# Altium schematic sheet 2001-SCM-0001-06.02 TITLE.SchDoc("2001-SCM-0001-06.02 TITLE")
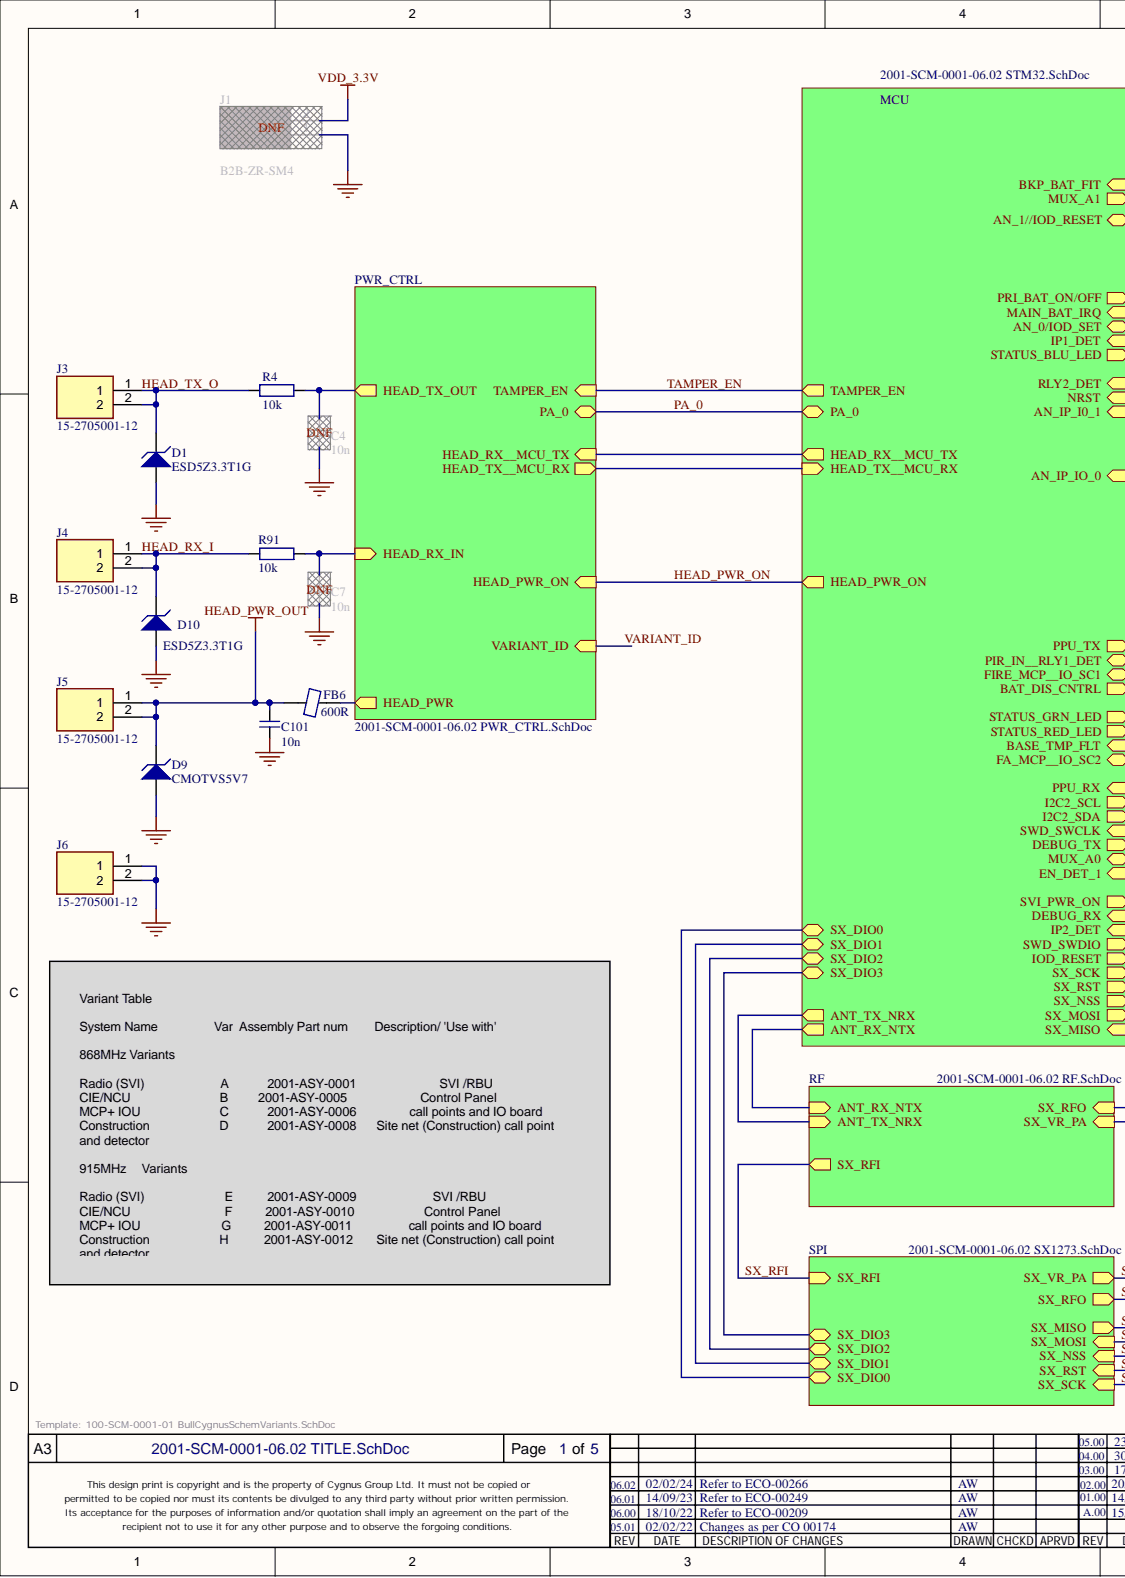
[diagram p0: 2001-SCM-0001-06.02 TITLE.SchDoc, left]
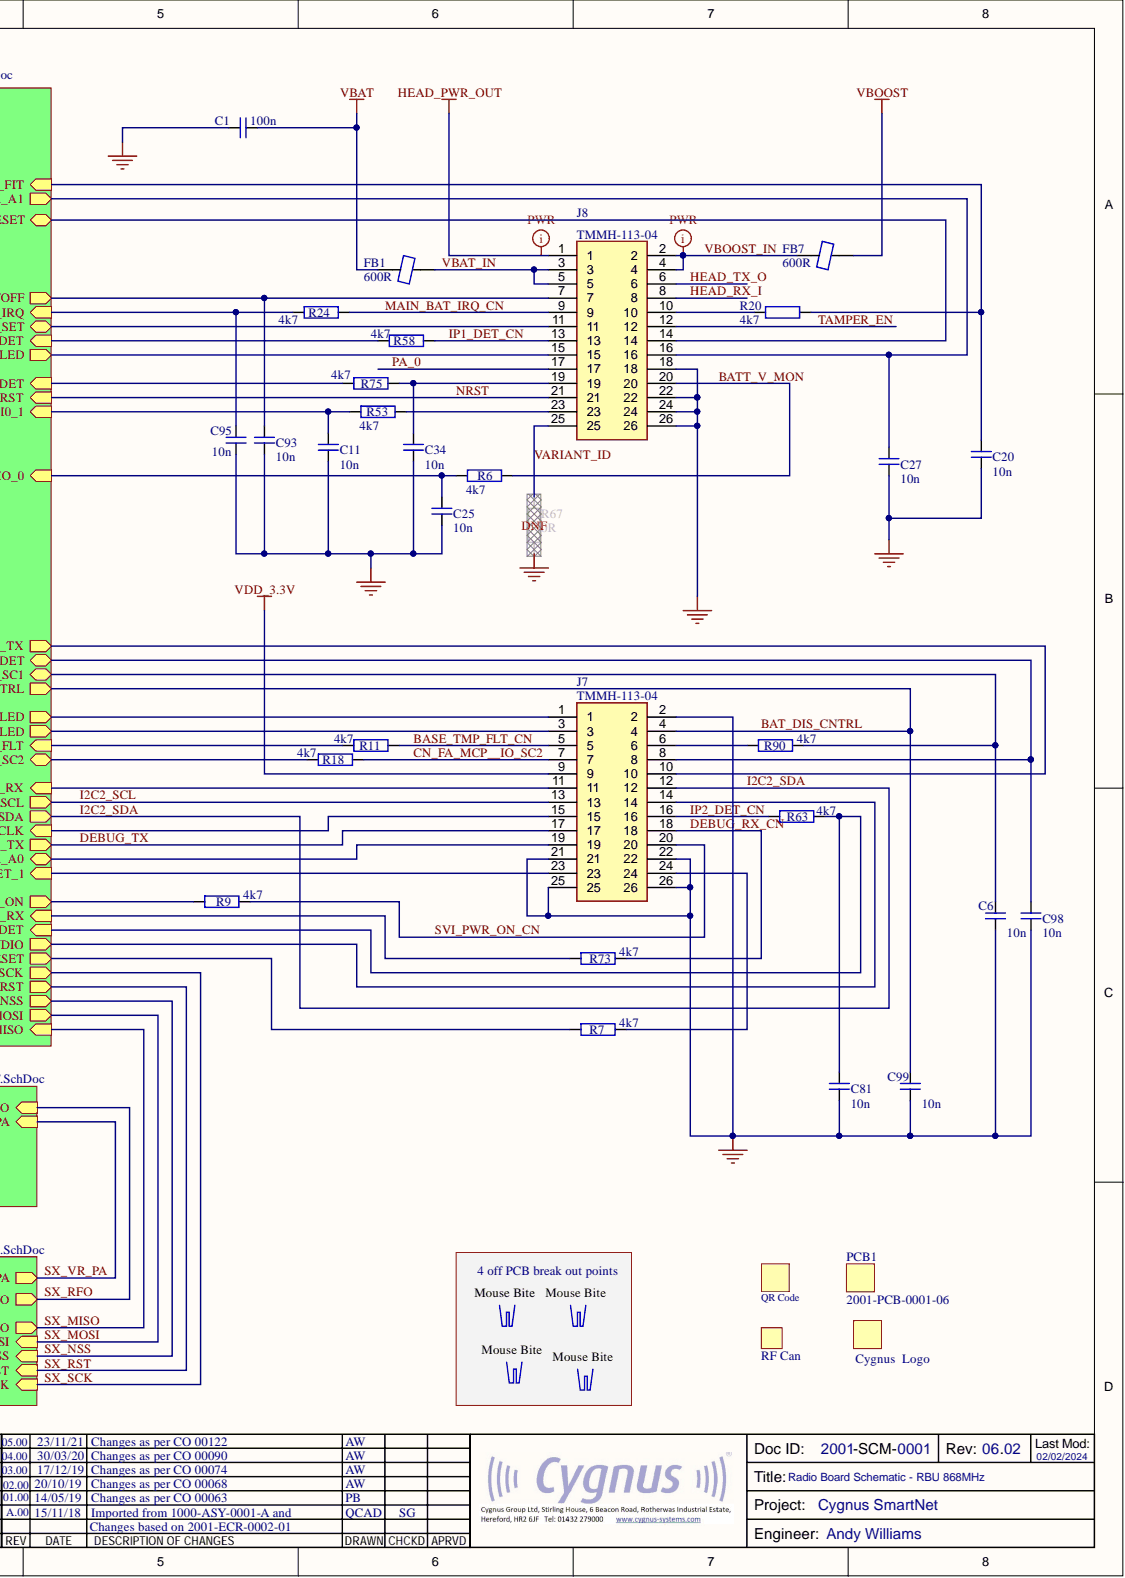
[diagram p1: 2001-SCM-0001-06.02 TITLE.SchDoc, right]

## Parts
C1  value 100n  [CAPC1608X95L]  (hidden comment: 100n 0603 16V X7R 10%)
C4  value 10n  [CAPC1608X95L]  (hidden comment: 10n 0603 16V X7R 10%)
C6  value 10n  [CAPC1608X95L]  (hidden comment: 10n 0603 16V X7R 10%)
C7  value 10n  [CAPC1608X95L]  (hidden comment: 10n 0603 16V X7R 10%)
C11  value 10n  [CAPC1608X95N]  (hidden comment: 10n 0603 16V X7R 10%)
C20  value 10n  [CAPC1608X95L]  (hidden comment: 10n 0603 16V X7R 10%)
C25  value 10n  [CAPC1608X95N]  (hidden comment: 10n 0603 16V X7R 10%)
C27  value 10n  [CAPC1608X95L]  (hidden comment: 10n 0603 16V X7R 10%)
C34  value 10n  [CAPC1608X95L]  (hidden comment: 10n 0603 16V X7R 10%)
C81  value 10n  [CAPC1608X95L]  (hidden comment: 10n 0603 16V X7R 10%)
C93  value 10n  [CAPC1608X95L]  (hidden comment: 10n 0603 16V X7R 10%)
C95  value 10n  [CAPC1608X95L]  (hidden comment: 10n 0603 16V X7R 10%)
C98  value 10n  [CAPC1608X95L]  (hidden comment: 10n 0603 16V X7R 10%)
C99  value 10n  [CAPC1608X95L]  (hidden comment: 10n 0603 16V X7R 10%)
C101  value 10n  [CAPC1608X95L]  (hidden comment: 10n 0603 16V X7R 10%)
D1  value ESD5Z3.3T1G  [SOD523_L]  (hidden comment: ESD5Z3.3T1G TVS Unidirectional SOD523 3V3 40A)
D9  value CMOTVS5V7  [SOD523_L]  (hidden comment: CMOTVS5V7 TVS Unidirectional SOD523 4.0V 40A)
D10  value ESD5Z3.3T1G  [SOD523_L]  (hidden comment: ESD5Z3.3T1G TVS Unidirectional SOD523 3V3 40A)
FB1  value 600R  [FBC1608X095L]  (hidden comment: 600R 0603 500mA 25%)
FB6  value 600R  [FBC1608X095L]  (hidden comment: 600R 0603 500mA 25%)
FB7  value 600R  [FBC1608X095L]  (hidden comment: 600R 0603 500mA 25%)
J1  value B2B-ZR-SM4  [CONN-JST-B2B-ZR-SM4-TF]  (hidden comment: JST B2B ZR 2Way SMD V 1P5)
J3  value 15-2705001-12  [CONN-NAE-15-0003]  (hidden comment: PCB Pin 15-2705001-12)
J4  value 15-2705001-12  [CONN-NAE-15-0003]  (hidden comment: PCB Pin 15-2705001-12)
J5  value 15-2705001-12  [CONN-NAE-15-0003]  (hidden comment: PCB Pin 15-2705001-12)
J6  value 15-2705001-12  [CONN-NAE-15-0003]  (hidden comment: PCB Pin 15-2705001-12)
J7  value TMMH-113-04  [CON_SAMTEC_TMMH-113-04-DV-ES-A-M-TR]  (hidden comment: Samtec TMMH 26Way SMD V 2P)
J8  value TMMH-113-04  [CON_SAMTEC_TMMH-113-04-DV-ES-A-M-TR]  (hidden comment: Samtec TMMH 26Way SMD V 2P)
Logo  Cygnus  Logo  [Cygnus Logo]  (no BOM)
MB1  MouseBite  [MouseBIte]  (no BOM)
MB2  MouseBite  [MouseBIte]  (no BOM)
MB3  MouseBite  [MouseBIte]  (no BOM)
MB4  MouseBite  [MouseBIte]  (no BOM)
Mech1  RF Can  (mechanical)
PCB1  2001-PCB-0001-06  (mechanical)
QR_Code  PCB Laser Etched QR Code  [PCB QR+HR CODE]  (value not drawn)  (no BOM)
R4  value 10k  [RESC1608L]  (hidden comment: 10K 0603 100mW 1%)
R6  value 4k7  [RESC1608L]  (hidden comment: 4K7 0603 100mW 1%)
R7  value 4k7  [RESC1608L]  (hidden comment: 4K7 0603 100mW 1%)
R9  value 4k7  [RESC1608L]  (hidden comment: 4K7 0603 100mW 1%)
R11  value 4k7  [RESC1608L]  (hidden comment: 4K7 0603 100mW 1%)
R18  value 4k7  [RESC1608L]  (hidden comment: 4K7 0603 100mW 1%)
R20  value 4k7  [RESC1608L]  (hidden comment: 4K7 0603 100mW 1%)
R24  value 4k7  [RESC1608L]  (hidden comment: 4K7 0603 100mW 1%)
R53  value 4k7  [RESC1608L]  (hidden comment: 4K7 0603 100mW 1%)
R58  value 4k7  [RESC1608L]  (hidden comment: 4K7 0603 100mW 1%)
R63  value 4k7  [RESC1608L]  (hidden comment: 4K7 0603 100mW 1%)
R67  value 0R  [RESC1608L]  (hidden comment: 0R 0603)
R73  value 4k7  [RESC1608L]  (hidden comment: 4K7 0603 100mW 1%)
R75  value 4k7  [RESC1608L]  (hidden comment: 4K7 0603 100mW 1%)
R90  value 4k7  [RESC1608L]  (hidden comment: 4K7 0603 100mW 1%)
R91  value 10k  [RESC1608L]  (hidden comment: 10K 0603 100mW 1%)

## Nets
GND: C1.2 C4.1 C6.2 C7.1 C11.1 C20.1 C25.1 C27.1 C34.1 C81.1 C93.1 C95.1 C98.2 C99.1 C101.1 D1.2 D9.2 D10.2 J1.1 J6.1 J6.2 J7.2 J7.21 J7.22 J7.25 J7.26 J8.18 J8.22 J8.24 J8.26 R67.2
HEAD_PWR_OUT: C101.2 D9.1 FB6.1 J5.1 J5.2 J8.1
VBAT: C1.1 FB1.1
VBOOST: FB7.2
VDD_3.3V: J1.2 J7.9
BASE_TMP_FLT_CN: J7.5 R11.1
BAT_DIS_CNTRL: C99.2 J7.4
BATT_V_MON: J8.20 R6.2
CN_FA_MCP__IO_SC2: J7.7 R18.1
DEBUG_RX_CN: J7.18 R73.1
DEBUG_TX: J7.17
HEAD_RX_I: D10.1 J4.1 J4.2 J8.8 R91.1
HEAD_TX_O: D1.1 J3.1 J3.2 J8.6 R4.1
I2C2_SCL: J7.13
I2C2_SDA: J7.12
IP1_DET_CN: J8.13 R58.2
IP2_DET_CN: J7.16 R63.2
MAIN_BAT_IRQ_CN: J8.9 R24.1
NRST: J8.21
PA_0: J8.17
SVI_PWR_ON_CN: J7.20 R9.1
TAMPER_EN: J8.12
VARIANT_ID: J8.25 R67.1
VBAT_IN: FB1.2 J8.3 J8.5
VBOOST_IN: FB7.1 J8.2 J8.4
NetC4_2: C4.2 R4.2
NetC6_1: C6.1 R90.1
NetC7_2: C7.2 R91.2
NetC11_2: C11.2 R53.2
NetC20_2: C20.2 R20.1
NetC25_2: C25.2 R6.1
NetC27_2: C27.2 J8.16
NetC34_2: C34.2 J8.19 R75.1
NetC81_2: C81.2 R63.1
NetC93_2: C93.2 J8.7
NetC95_2: C95.2 R24.2
NetC98_1: C98.1 J7.8
NetFB6_2: FB6.2
NetJ7_1: J7.1
NetJ7_3: J7.3
NetJ7_6: J7.6 R90.2
NetJ7_10: J7.10
NetJ7_11: J7.11
NetJ7_14: J7.14
NetJ7_15: J7.15
NetJ7_19: J7.19
NetJ7_23: J7.23
NetJ7_24: J7.24 R7.1
NetJ8_10: J8.10 R20.2
NetJ8_11: J8.11
NetJ8_14: J8.14
NetJ8_15: J8.15
NetJ8_23: J8.23 R53.1
NetR7_2: R7.2
NetR9_2: R9.2
NetR11_2: R11.2
NetR18_2: R18.2
NetR58_1: R58.1
NetR73_2: R73.2
NetR75_2: R75.2
HEAD_PWR_ON:
N00167:
N00168:
N00169:
N00170:
N00171:
N00172:
N00173:
N00174:
SX_MISO:
SX_MOSI:
SX_NSS:
SX_RFI:
SX_RFO:
SX_RST:
SX_SCK:
SX_VR_PA:

## Library data of the parts
C1 = 100n-0603-16V-X7R-10%: Voltage: 16V; Tolerance: 10%; Package: 0603; Manufacturer Part Number 1: 100n-0603-16V-X7R-10%; Library Name: Capacitors.IntLib; Dielectric: X7R; Description: 100n 0603 16V X7R 10%; Component Kind: Standard; Category: Ceramic
C4 C6 C7 C11 C20 C25 C27 C34 C81 C93 C95 C98 C99 C101 = 10n-0603-16V-X7R-10%: Voltage: 16V; Tolerance: 10%; Package: 0603; Manufacturer Part Number 1: 10n-0603-16V-X7R-10%; Dielectric: X7R; Description: 10n 0603 16V X7R 10%; Component Kind: Standard; Category: Ceramic
  C6 C20 C25 C27 C34 C81 C93 C95 C98 C99 C101: Library Name: Development.IntLib
  C4 C7 C11: Library Name: 2001-ASY-0001-A.00 Radio Board.IntLib
D1 D10 = ESD5Z3.3T1G TVS Unidirectional SOD523 3V3 40A: TVS Unidirectional SOD523 3V3 40A; Manufacturer Part Number 1: ESD5Z3.3T1G1; Manufacturer 1: ON Semiconductor; Database Table Name: Diodes; Temperature: -55°C to +150°C; Supplier Part Number 1: 2317508; Supplier 1: Farnell; Package: SOD523; Component Kind: Standard; Category: TVS; Library Name: BPL_Altium_Database_01.DbLib
D9 = CMOTVS5V7 TVS Unidirectional SOD523 4.0V 40A: TVS Unidirectional SOD523 4.0V 40A; Manufacturer 1: Central Semiconductor; Database Table Name: Diodes; Temperature: -55°C to +150°C; Supplier Part Number 1: 1514-CMOTVS5V7TRPBFREECT-ND; Supplier 1: DigiKey; Package: SOD523; Manufacturer Part Number 1: CMOTVS5V7; Component Kind: Standard; Category: TVS; Library Name: BPL_Altium_Database_01.DbLib
FB1 FB6 FB7 = 600R-0603-500mA-25%: Manufacturer Part Number 1: BLM18AG601SN1D; Manufacturer 1: Murata; Tolerance: 25%; Temperature: -55°C to +125°C; Supplier Part Number 1: 490-1014-1-ND; Supplier 1: Digi-Key; Rating: 500mA; Package: 0402; Library Name: Development.IntLib; Description: 600R 0603 500mA 25%; Component Kind: Standard; Category: Ferrite
J1 = JST-B2B ZR-2Way-SMD-V-1P5: Manufacturer Part Number 1: B2B-ZR-SM4-TF(LF)(SN); Temperature: -25°C ~ 85°C; Supplier Part Number 1: 455-1681-1-ND; Supplier 1: Digi-Key; Manufacturer 1: JST; Library Name: Connectors.IntLib; Description: JST B2B ZR 2Way SMD V 1P5; Component Kind: Standard; Category: Header
J3-J6 = PCB Pin 15-2705001-12: Manufacturer 1: Numens; Manufacturer Part Number 1: 15-2705001-12; Description: PCB Pin 15-2705001-12; Component Kind: Standard; Library Name: Andy Sch Lib.SchLib
J7 J8 = Samtec-TMMH-26Way-EBC-SMD-V-2P: Manufacturer Part Number 1: TMMH-113-04-F-DV--ES-A-M-TR; Qualified: UNCHECKED; Temperature: -25°C ~ 85°C; Supplier Part Number 1: TMMH-113-04-F-DV--ES-A-M-TR; Supplier 1: Samtec; Package: TMMH; Manufacturer 1: Samtec; Description: Samtec TMMH 26Way SMD V 2P; Component Kind: Standard; Category: Header; Library Name: Andy Sch Lib.SchLib
Logo = Cygnus Logo: Library Name: Andy Sch Lib.SchLib
Mech1 = RF Can (radio board): RF Screening can for Radio PCB; Manufacturer Part Number 1: 2001-PRT-0007-04; Manufacturer 1: Cygnus Systems; Library Name: Andy Sch Lib.SchLib
PCB1 = PCB: Bare PCB; Manufacturer Part Number 1: 2001-PCB-0001-06; Library Name: Andy Sch Lib.SchLib
QR_Code = PCB Laser Etched QR Code: Database Table Name: Miscellaneous; Critical: N; Description: PCB Laser Etched QR Code; Category: PCB Legend; Library Name: BPL_Altium_Database_01.DbLib
R4 R91 = 10K-0603-100mW-1%: Wattage: 100mW; Tolerance: 1%; Package: 0603; Manufacturer Part Number 1: 10K-0603-100mW-1%; Library Name: Development.IntLib; Description: 10K 0603 100mW 1%; Component Kind: Standard; Category: Thick Film
R6 R7 R9 R11 R18 R20 R24 R53 R58 R63 R73 R75 R90 = 4K7-0603-100mW-1%: Wattage: 100mW; Tolerance: 1%; Package: 0603; Manufacturer Part Number 1: 4K7-0603-100mW-1%; Description: 4K7 0603 100mW 1%; Component Kind: Standard; Category: Thick Film
  R11 R20 R24 R58 R63 R73 R75 R90: Library Name: Development.IntLib
  R6 R7 R9 R18 R53: Library Name: 2001-ASY-0001-A.00 Radio Board.IntLib
R67 = 0R0-0603-100mW-1%: Manufacturer Part Number 1: 0R-0603; Wattage: 100mW; Tolerance: 1%; Qualified: CHECKED; Package: 0603; Description: 0R 0603; Component Kind: Standard; Category: Thick Film; Library Name: Andy Sch Lib.SchLib
MB1-MB4 = MouseBite: Library Name: PB_NEW.SchLib
